# Altium schematic sheet DigiFab_no_MCU.SchDoc(DigiFab_no_MCU)
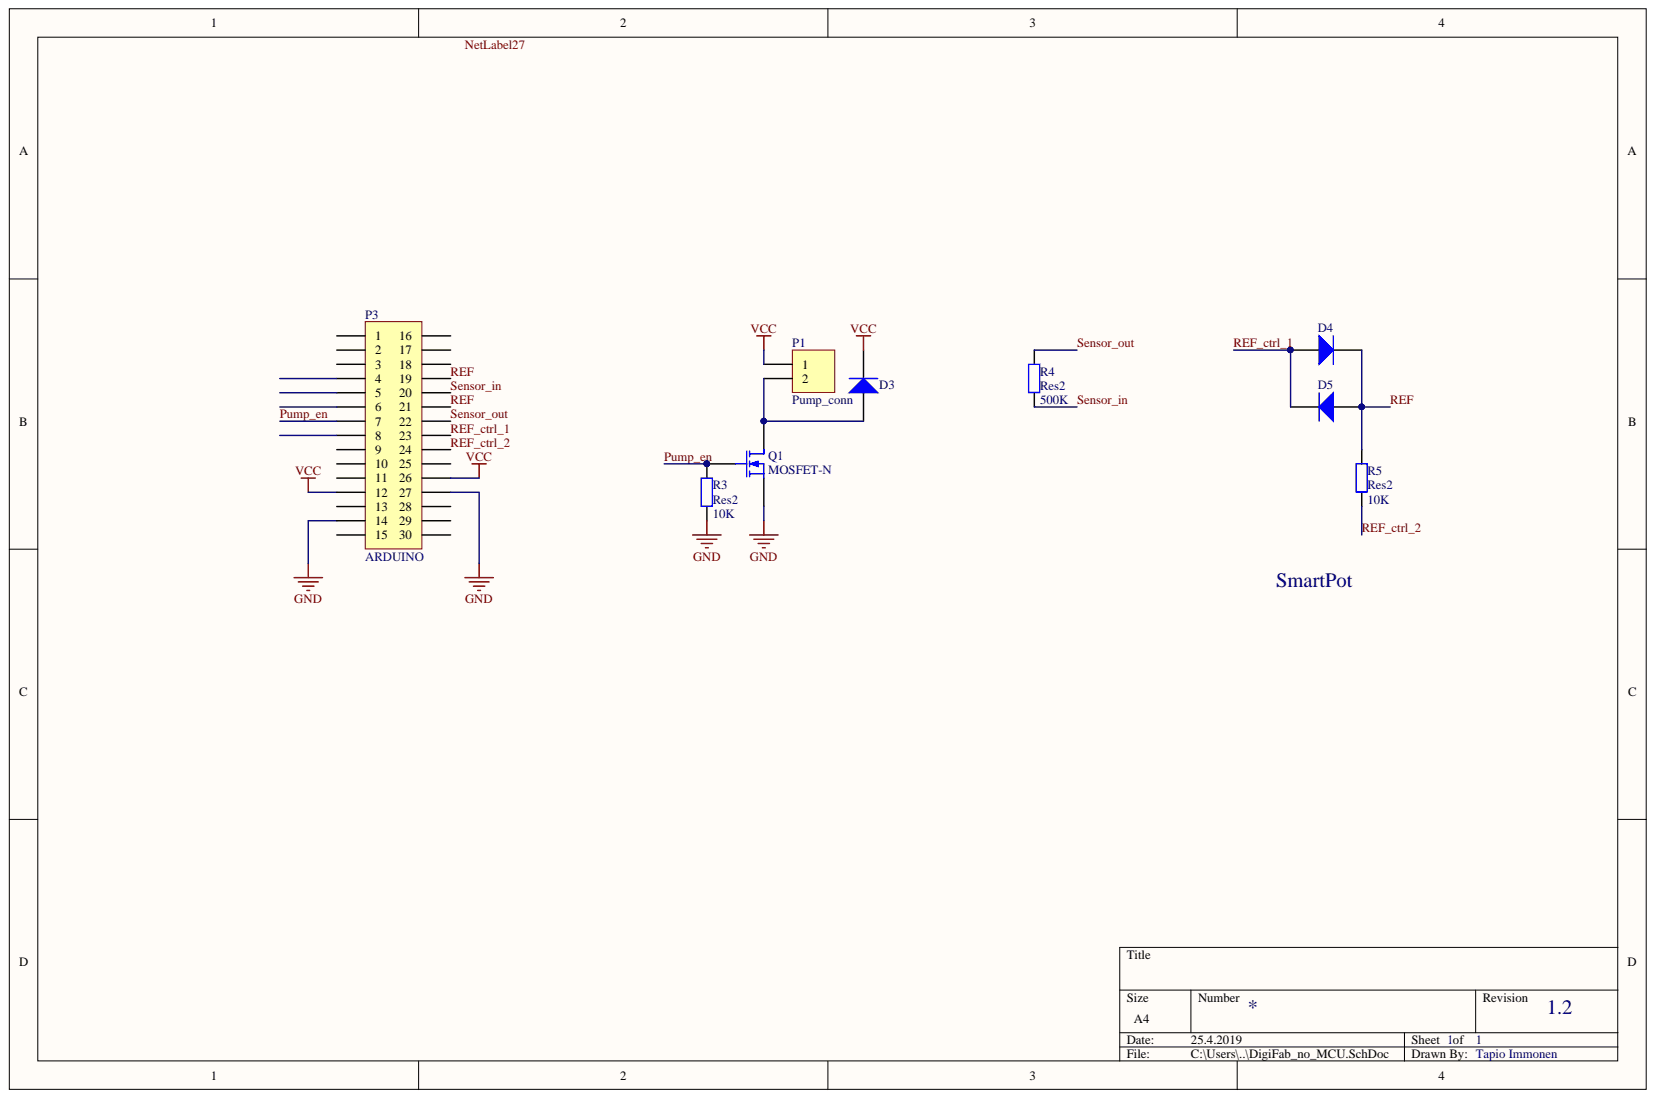
[diagram p0: DigiFab_no_MCU.SchDoc]

## Parts
D3  Diode  [DO-7]  (value not drawn)
D4  Diode  [DO-7]  (value not drawn)
D5  Diode  [DO-7]  (value not drawn)
P1  Pump_conn  [HDR1X2]
P3  ARDUINO  [Arduino_nano]
Q1  MOSFET-N  [SOT95P245X110-3N]
R3  Res2  value 10K  [C1206]
R4  Res2  value 500K  [C1206]
R5  Res2  value 10K  [AXIAL-0.5]

## Nets
GND: P3.14 P3.27 Q1.2 R3.1
VCC: D3.2 P1.1 P3.12 P3.26
PUMP_EN: P3.7 Q1.1 R3.2
REF: D4.2 D5.1 P3.19 P3.21 R5.1
REF_CTRL_1: D4.1 D5.2 P3.23
REF_CTRL_2: P3.24 R5.2
SENSOR_IN: P3.20 R4.2
SENSOR_OUT: P3.22 R4.1
NetD3_1: D3.1 P1.2 Q1.3
NETLABEL27:

Not connected: P3 1 2 3 4 5 6 8 9 10 11 13 15 16 17 18 25 28 29 30

## Library data of the parts
D3-D5 = Diode: Default Diode; Code_JEDEC: DO-214-AB; Component Kind: Standard; LatestRevisionDate: 17-Jul-2002; LatestRevisionNote: Re-released for DXP Platform.; Library Name: Miscellaneous Devices.IntLib; PackageDescription: DO-214-AB/SMC; 2 C-Bend Leads; Body 7.9 x 5.9 mm, inc. leads (LxW); PackageReference: SMC; PackageVersion: Sep-1996; Published: 8-Jun-2000; Signal Integrity: Diode; Simulation: DIODE; Publisher: Altium Limited
P1 = Header 2: Header, 2-Pin; Component Kind: Standard; LatestRevisionDate: 17-Jul-2002; LatestRevisionNote: Re-released for DXP Platform.; Library Name: Miscellaneous Connectors.IntLib; Signal Integrity: Connector; Publisher: Altium Limited
P3 = Header 15X2A: Header, 15-Pin, Dual row; Component Kind: Standard; LatestRevisionDate: 17-Jul-2002; LatestRevisionNote: Re-released for DXP Platform.; Library Name: Miscellaneous Connectors.IntLib; Signal Integrity: Connector; Publisher: Altium Limited
Q1 = MOSFET-N: N-Channel MOSFET; Component Kind: Standard; LatestRevisionDate: 17-Jul-2002; LatestRevisionNote: Re-released for DXP Platform.; Library Name: Miscellaneous Devices.IntLib; PackageDescription: TO, Flat Index; 3 In-Line, Axial Leads; Body Dia. 4.6mm; Lead Dia. 0.5 mm (max); PackageReference: E3; PackageVersion: 1995/1996; Published: 8-Jun-2000; Simulation: NMOS; Publisher: Altium Limited
R3-R5 = Res2: Resistor; Component Kind: Standard; LatestRevisionDate: 17-Jul-2002; LatestRevisionNote: Re-released for DXP Platform.; Library Name: Miscellaneous Devices.IntLib; PackageDescription: Axial Device, Thru-Hole; 2 Leads; 0.4 in Pin Spacing; PackageReference: AXIAL-0.4; Published: 8-Jun-2000; Signal Integrity: Res; Simulation: RESISTOR; Publisher: Altium Limited
